# Altium schematic sheet Uart_and_Daylight_Sensor.SchDoc
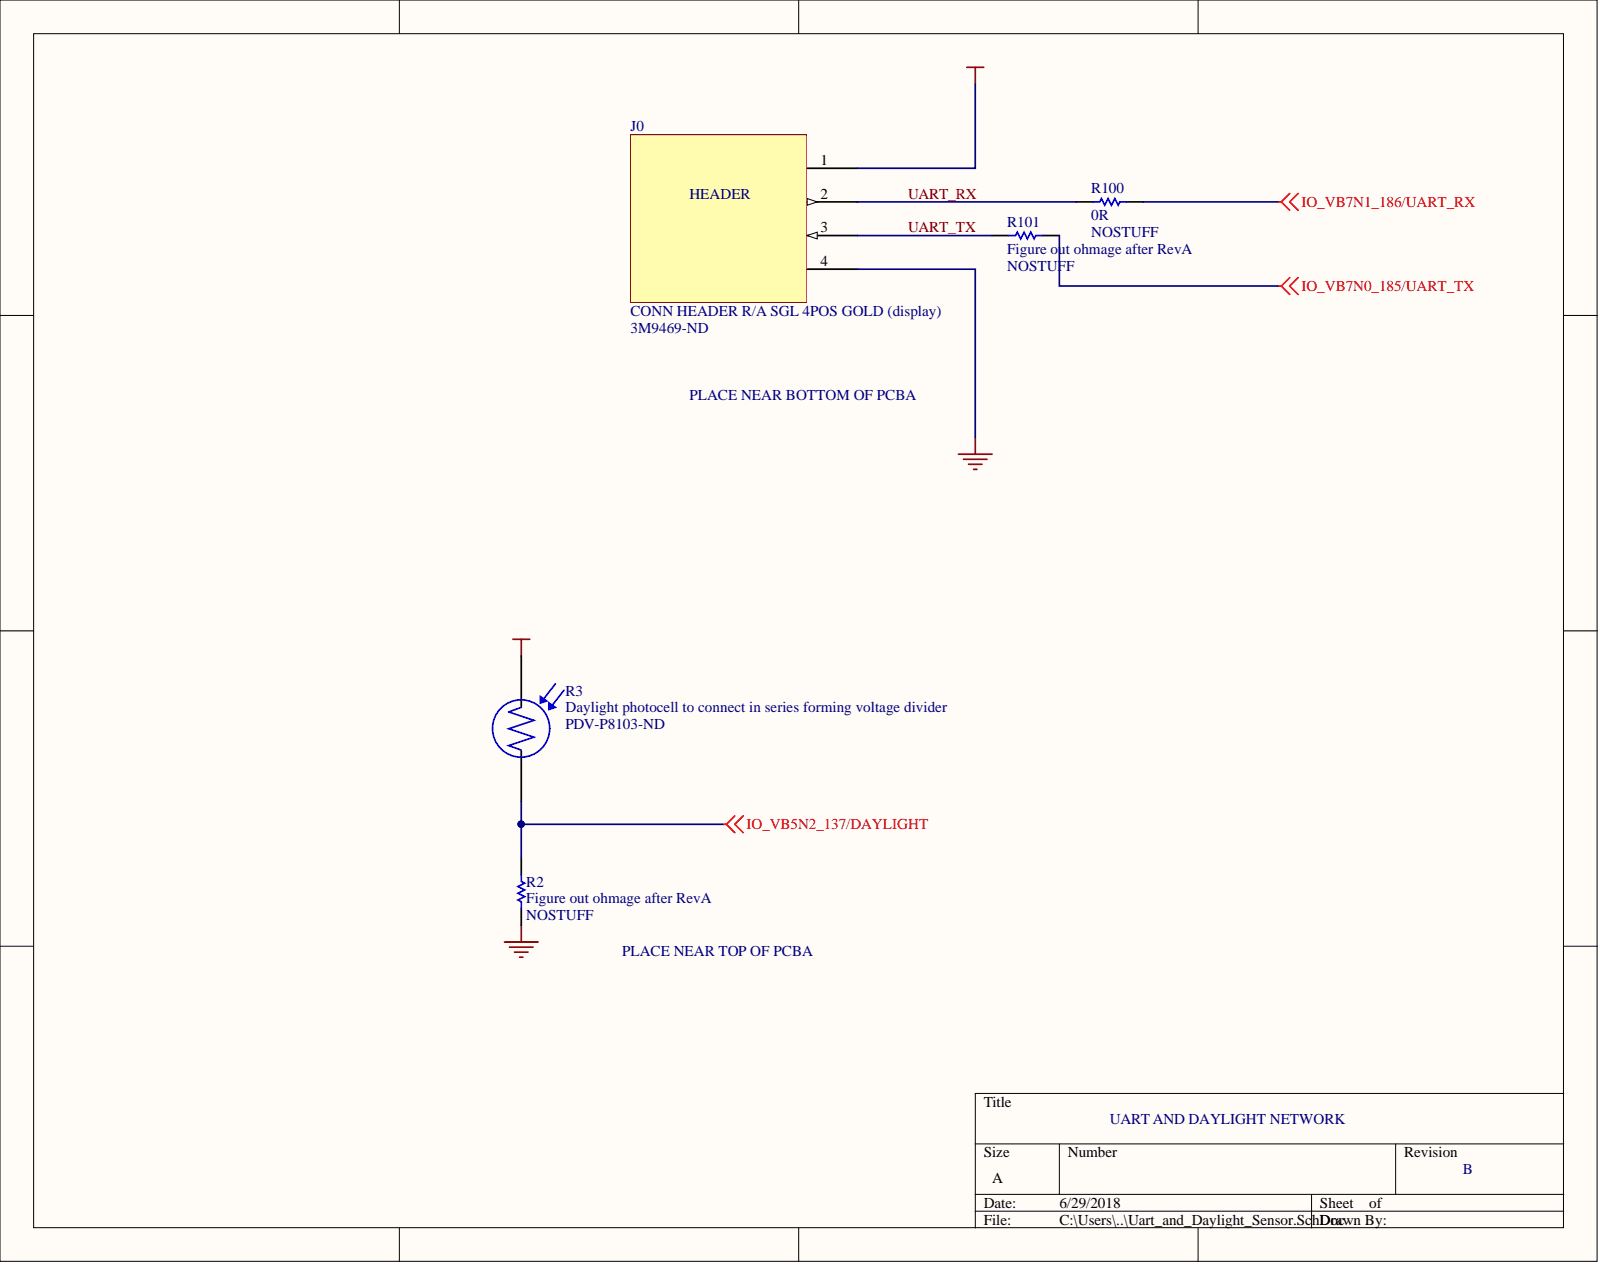
[diagram p0: Uart_and_Daylight_Sensor.SchDoc]

## Parts
J0  CONN HEADER R/A SGL 4POS GOLD (display)  Supplier Part Number 1: 3M9469-ND  [HEADER_4POS]
R2  Figure out ohmage after RevA  value NOSTUFF  [RES_0603]
R3  Daylight photocell to connect in series forming voltage divider  Supplier Part Number 1: PDV-P8103-ND  [RES_NSL-19M51]
R100  0R  value NOSTUFF  [RES_0603]
R101  Figure out ohmage after RevA  value NOSTUFF  [RES_0603]

## Nets
3V3: R3.1
5V0: J0.1
GND: J0.4 R2.1
IO_VB5N2_137/DAYLIGHT: R2.2 R3.2
IO_VB7N0_185/UART_TX: R101.1
IO_VB7N1_186/UART_RX: R100.2
UART_RX: J0.2 R100.1
UART_TX: J0.3 R101.2

## Library data of the parts
J0 = CONN HEADER R/A SGL 4POS GOLD (display): http://multimedia.3m.com/mws/media/548122O/3mtm-pin-strip-header-ts2182.pdf; Component Kind: Standard; Library Name: schematics.SchLib; Supplier 1: Digi-Key
R2 R100 R101 = Res1: Resistor; Component Kind: Standard; LatestRevisionDate: 17-Jul-2002; LatestRevisionNote: Re-released for DXP Platform.; Library Name: Miscellaneous Devices.IntLib; PackageDescription: Axial Device, Thru-Hole; 2 Leads; 0.3 in Pin Spacing; PackageReference: AXIAL-0.3; Published: 8-Jun-2000; Signal Integrity: Res; Simulation: RESISTOR; Publisher: Altium Limited
R3 = PHOTOCELL 16-33KOHM: http://media.digikey.com/pdf/Data%20Sheets/Photonic%20Detetectors%20Inc%20PDFs/PDV-P8103.pdf; Component Kind: Standard; Library Name: schematics.SchLib; Supplier 1: Digi-Key
